# Altium schematic sheet Sumotop_Connectors_WB_SCH.SchDoc
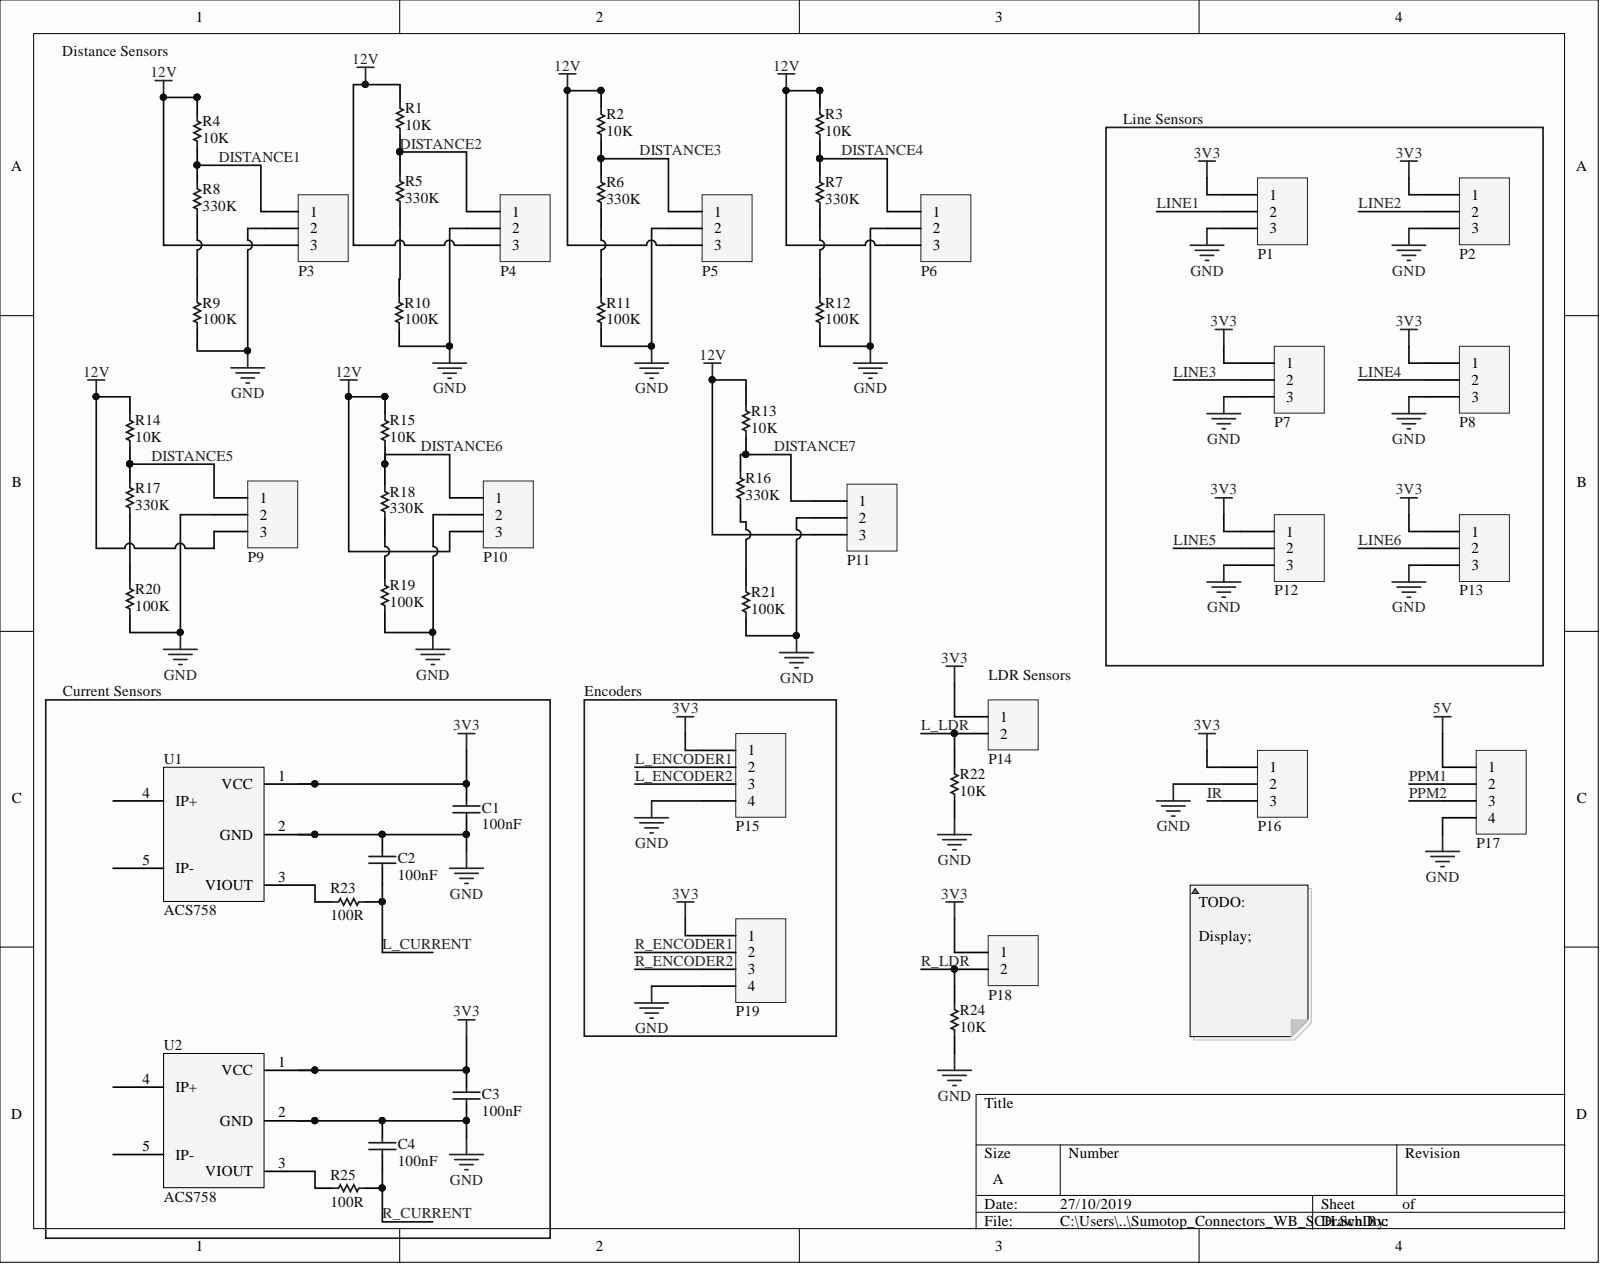
[diagram p0: Sumotop_Connectors_WB_SCH.SchDoc]

## Parts
C1  100nF  [Capacitor-0603]
C2  100nF  [Capacitor-0603]
C3  100nF  [Capacitor-0603]
C4  100nF  [Capacitor-0603]
P1  Header 1x3  [HDR MALE 1x3]  (value not drawn)
P2  Header 1x3  [HDR MALE 1x3]  (value not drawn)
P3  Header 1x3  [HDR MALE 1x3]  (value not drawn)
P4  Header 1x3  [HDR MALE 1x3]  (value not drawn)
P5  Header 1x3  [HDR MALE 1x3]  (value not drawn)
P6  Header 1x3  [HDR MALE 1x3]  (value not drawn)
P7  Header 1x3  [HDR MALE 1x3]  (value not drawn)
P8  Header 1x3  [HDR MALE 1x3]  (value not drawn)
P9  Header 1x3  [HDR MALE 1x3]  (value not drawn)
P10  Header 1x3  [HDR MALE 1x3]  (value not drawn)
P11  Header 1x3  [HDR MALE 1x3]  (value not drawn)
P12  Header 1x3  [HDR MALE 1x3]  (value not drawn)
P13  Header 1x3  [HDR MALE 1x3]  (value not drawn)
P14  Header 1x2  [HDR1X2]  (value not drawn)
P15  Header 1x4  [HDR MALE 1x4]  (value not drawn)
P16  Header 1x3  [HDR MALE 1x3]  (value not drawn)
P17  Header 1x4  [HDR MALE 1x4]  (value not drawn)
P18  Header 1x2  [HDR1X2]  (value not drawn)
P19  Header 1x4  [HDR MALE 1x4]  (value not drawn)
R1  10K  [RESISTOR 0603]
R2  10K  [RESISTOR 0603]
R3  10K  [RESISTOR 0603]
R4  10K  [RESISTOR 0603]
R5  330K  [RESISTOR 0603]
R6  330K  [RESISTOR 0603]
R7  330K  [RESISTOR 0603]
R8  330K  [RESISTOR 0603]
R9  100K  [RESISTOR 0603]
R10  100K  [RESISTOR 0603]
R11  100K  [RESISTOR 0603]
R12  100K  [RESISTOR 0603]
R13  10K  [RESISTOR 0603]
R14  10K  [RESISTOR 0603]
R15  10K  [RESISTOR 0603]
R16  330K  [RESISTOR 0603]
R17  330K  [RESISTOR 0603]
R18  330K  [RESISTOR 0603]
R19  100K  [RESISTOR 0603]
R20  100K  [RESISTOR 0603]
R21  100K  [RESISTOR 0603]
R22  10K  [RESISTOR 0603]
R23  100R  [RESISTOR 0603]
R24  10K  [RESISTOR 0603]
R25  100R  [RESISTOR 0603]
U1  ACS758  [ACS758]
U2  ACS758  [ACS758]

## Nets
3V3: C1.2 C3.2 P1.1 P2.1 P7.1 P8.1 P12.1 P13.1 P14.1 P15.1 P16.1 P18.1 P19.1 U1.1(VCC) U2.1(VCC)
5V: P17.1
12V: P3.3 P4.3 P5.3 P6.3 P9.3 P10.3 P11.3 R1.2 R2.2 R3.2 R4.2 R13.2 R14.2 R15.2
GND: C1.1 C2.2 C3.1 C4.2 P1.3 P2.3 P3.2 P4.2 P5.2 P6.2 P7.3 P8.3 P9.2 P10.2 P11.2 P12.3 P13.3 P15.4 P16.2 P17.4 P19.4 R9.1 R10.1 R11.1 R12.1 R19.1 R20.1 R21.1 R22.1 R24.1 U1.2(GND) U2.2(GND)
DISTANCE1: P3.1 R4.1 R8.2
DISTANCE2: P4.1 R1.1 R5.2
DISTANCE3: P5.1 R2.1 R6.2
DISTANCE4: P6.1 R3.1 R7.2
DISTANCE5: P9.1 R14.1 R17.2
DISTANCE6: P10.1 R15.1 R18.2
DISTANCE7: P11.1 R13.1 R16.2
IR: P16.3
L_CURRENT: C2.1 R23.2
L_ENCODER1: P15.2
L_ENCODER2: P15.3
L_LDR: P14.2 R22.2
LINE1: P1.2
LINE2: P2.2
LINE3: P7.2
LINE4: P8.2
LINE5: P12.2
LINE6: P13.2
PPM1: P17.2
PPM2: P17.3
R_CURRENT: C4.1 R25.2
R_ENCODER1: P19.2
R_ENCODER2: P19.3
R_LDR: P18.2 R24.2
NetR5_1: R5.1 R10.2
NetR6_1: R6.1 R11.2
NetR7_1: R7.1 R12.2
NetR8_1: R8.1 R9.2
NetR16_1: R16.1 R21.2
NetR17_1: R17.1 R20.2
NetR18_1: R18.1 R19.2
NetR23_1: R23.1 U1.3(VIOUT)
NetR25_1: R25.1 U2.3(VIOUT)

Not connected: U1 4(IP+) 5(IP-); U2 4(IP+) 5(IP-)

## Library data of the parts
C1-C4 = CAP 100NF 50V: Capacitor Cer disk 100nF 50V; Manufacturer Part Number: IMP14925; Capacitance: 0.1uF; Capacitance Tolerance: +80%, -20%; Capacitor Terminals: Radial Leaded; Dielectric Characteristic: Y5; Product Range: MCFY Series; SVHC: No SVHC (15-Jun-2015); Voltage Rating: 50; Capacitance Tolerance ±: +80%, -20%; Component Kind: Standard; ComponentLink1Description: http://loja.multcomercial.com.br/capacitor-ceramico-disco-100kpf-50v-104-100k-100nf-0-1uf-cod-1351.html; ComponentLink1URL: http://loja.multcomercial.com.br/capacitor-ceramico-disco-100kpf-50v-104-100k-100nf-0-1uf-cod-1351.html; Lead Spacing: 5; Manufacturer: -; Operating Temperature Max: 85; Operating Temperature Min: -25; Operating Temperature Range: -25°C to +85°C<!--FANDB--><div class="features-and-benefits-container"><div class="features-and-benefits-description"><span class="features-benefits-value">The MCFY series from Multicomp are radial leaded ceramic disc capacitors. The capacitance values ranges from 6800pF to 0.22?F.</span></div><div class="features-and-benefits-list"><ul class="features-and-benefits-list-list"><li class="features-and-benefits-list-item">Large capacitance in small size</li><li class="features-and-benefits-list-item">Rated voltage of 50V</li><li class="features-and-benefits-list-item">80%/-20% tolerance</li><li class="features-and-benefits-list-item">Operating temperature range -25°C to +85; Packaging: Each; Pricing 1: 1=0.10 (BRL); RoHS: YES; Stock 1: 115809; Supplier 1: Mult Comercial; Supplier Part Number 1: Capacitor Cerâmico Disco 100Kpf/50V (104/100K/100nF/0.1uF) cód. 1351; Library Name: Sumotop_Control_WB_SCHLIB.SchLib
P1-P13 P16 = Header 1x3: Headers & Wire Housings 40Pin 2mm Spacing Single Row Hdrs, 5pc; Manufacturer Part Number: IMP10327; Brand: Cromax; Mounting Angle: Vertical; Number of Positions: 40 Position; Accessory Type: -; Category: Headers & Wire Housings; Component Kind: Standard; ComponentLink1Description: http://loja.multcomercial.com.br/barra-de-pinos-simples-1x40-macho-bmo40-1e.html; ComponentLink1URL: http://loja.multcomercial.com.br/barra-de-pinos-simples-1x40-macho-bmo40-1e.html; Contact Gender: Pin (Male); Contact Plating: Gold; Contact Type: -; Manufacturer: Cromax; Minimum Order Quantity: 1; Mounting Style: Straight Pin; Number of Rows: 1 Row; Pitch: 2 mm; Pricing 1: 1=1.00, 5=0.20 (BRL); Product: Headers; Product Category: Accessories; Reeling: False; RoHS: RoHS Compliant; RoHS - Mouser: Y; Row Spacing: -; Stock 1: 14; Supplier 1: Mult Comercial; Supplier Part Number 1: Barra de Pinos Simples 1x40 Macho BMO40-1E; Termination Style: Through Hole; Type: Breakaway; Library Name: Sumotop_Control.SchLib
P14 P18 = Header 1x2: Headers & Wire Housings 40Pin 2mm Spacing Single Row Hdrs, 5pc; Manufacturer Part Number: IMP10327; Brand: Cromax; Mounting Angle: Vertical; Number of Positions: 40 Position; Accessory Type: -; Category: Headers & Wire Housings; Component Kind: Standard; ComponentLink1Description: http://loja.multcomercial.com.br/barra-de-pinos-simples-1x40-macho-bmo40-1e.html; ComponentLink1URL: http://loja.multcomercial.com.br/barra-de-pinos-simples-1x40-macho-bmo40-1e.html; Contact Gender: Pin (Male); Contact Plating: Gold; Contact Type: -; Manufacturer: Cromax; Minimum Order Quantity: 1; Mounting Style: Straight Pin; Number of Rows: 1 Row; Pitch: 2 mm; Pricing 1: 1=1.00, 5=0.20 (BRL); Product: Headers; Product Category: Accessories; Reeling: False; RoHS: RoHS Compliant; RoHS - Mouser: Y; Row Spacing: -; Stock 1: 14; Supplier 1: Mult Comercial; Supplier Part Number 1: Barra de Pinos Simples 1x40 Macho BMO40-1E; Termination Style: Through Hole; Type: Breakaway; Library Name: Sumotop_Control.SchLib
P15 P17 P19 = Header 1x4: Headers & Wire Housings 40Pin 2mm Spacing Single Row Hdrs, 5pc; Manufacturer Part Number: IMP10327; Brand: Cromax; Mounting Angle: Vertical; Number of Positions: 40 Position; Accessory Type: -; Category: Headers & Wire Housings; Component Kind: Standard; ComponentLink1Description: http://loja.multcomercial.com.br/barra-de-pinos-simples-1x40-macho-bmo40-1e.html; ComponentLink1URL: http://loja.multcomercial.com.br/barra-de-pinos-simples-1x40-macho-bmo40-1e.html; Contact Gender: Pin (Male); Contact Plating: Gold; Contact Type: -; Manufacturer: Cromax; Minimum Order Quantity: 1; Mounting Style: Straight Pin; Number of Rows: 1 Row; Pitch: 2 mm; Pricing 1: 1=1.00, 5=0.20 (BRL); Product: Headers; Product Category: Accessories; Reeling: False; RoHS: RoHS Compliant; RoHS - Mouser: Y; Row Spacing: -; Stock 1: 14; Supplier 1: Mult Comercial; Supplier Part Number 1: Barra de Pinos Simples 1x40 Macho BMO40-1E; Termination Style: Through Hole; Type: Breakaway; Library Name: Sumotop_Control.SchLib
R1-R4 R13-R15 R22 R24 = RES 10K 5%: Manufacturer: PANASONIC ELECTRONIC COMPONENTS; Manufacturer Part Number: ERJ-3GEYJ103V; Power (Watts): 0.1W, 1/10W; Temperature Coefficient: ±200ppm/°C; Tolerance: ±5%; Category: Resistors; Component Kind: Standard; ComponentLink1Description: http://www.farnell.com/datasheets/1678796.pdf; ComponentLink1URL: http://www.farnell.com/datasheets/1678796.pdf; ComponentLink2Description: http://industrial.panasonic.com/www-cgi/jvcr13pz.cgi?E+PZ+3+AOA0001+ERJ3GEYJ103V+7+WW; ComponentLink2URL: http://industrial.panasonic.com/www-cgi/jvcr13pz.cgi?E+PZ+3+AOA0001+ERJ3GEYJ103V+7+WW; Composition: Thick Film; Features: Automotive AEC-Q200; Height: 0.022" (0.55mm); Number of Terminations: 2; Operating Temperature: -55°C ~ 155°C; Package / Case: 0603 (1608 Metric); Packaging: Tape & Reel (TR); Resistance (Ohms): 10k; RoHS: YES; Size / Dimension: 0.063" L x 0.031" W (1.60mm x 0.80mm); Supplier Device Package: 0603; Library Name: Sumotop_Control_WB_SCHLIB.SchLib
R5-R8 R16-R18 = RES 330K 5%: Category: Resistors; Component Kind: Standard; ComponentLink1Description: http://www.farnell.com/datasheets/1678796.pdf; ComponentLink1URL: http://www.farnell.com/datasheets/1678796.pdf; ComponentLink2Description: http://industrial.panasonic.com/www-cgi/jvcr13pz.cgi?E+PZ+3+AOA0001+ERJ3GEYJ103V+7+WW; ComponentLink2URL: http://industrial.panasonic.com/www-cgi/jvcr13pz.cgi?E+PZ+3+AOA0001+ERJ3GEYJ103V+7+WW; Resistance (Ohms): 330k; Library Name: Sumotop_Control_WB_SCHLIB.SchLib
R9-R12 R19-R21 = RES 100K 5%: Category: Resistors; Component Kind: Standard; ComponentLink1Description: http://www.farnell.com/datasheets/1678796.pdf; ComponentLink1URL: http://www.farnell.com/datasheets/1678796.pdf; ComponentLink2Description: http://industrial.panasonic.com/www-cgi/jvcr13pz.cgi?E+PZ+3+AOA0001+ERJ3GEYJ103V+7+WW; ComponentLink2URL: http://industrial.panasonic.com/www-cgi/jvcr13pz.cgi?E+PZ+3+AOA0001+ERJ3GEYJ103V+7+WW; Resistance (Ohms): 100k; Library Name: Sumotop_Control_WB_SCHLIB.SchLib
R23 R25 = RES 100R 5%: Manufacturer: Ty-Ohm; Manufacturer Part Number: CR25; Power (Watts): 0.25W, 1/4W; Temperature Coefficient: 0/ -500ppm/°C; Tolerance: ±5%; Category: Resistors; Component Kind: Standard; ComponentLink1Description: http://loja.multcomercial.com.br/resistor-de-1-4-de-watt-cr25-5-de-tolerancia.html; ComponentLink1URL: http://loja.multcomercial.com.br/resistor-de-1-4-de-watt-cr25-5-de-tolerancia.html; Composition: Carbon Film; Features: Flame Retardant Coating, Safety; Height: -; Number of Terminations: 2; Operating Temperature: -55°C ~ 155°C; Package / Case: Axial; Packaging: Cut Tape (CT); Pricing 1: 1=0.20 (BRL); Resistance (Ohms): ?; RoHS: RoHS Compliant; Size / Dimension: 0.091" Dia x 0.256" L (2.30mm x 6.50mm); Stock 1: 617543; Supplier 1: Mult Comercial; Supplier Device Package: Axial; Supplier Part Number 1: Resistor de Filme de Carbono 1/4 de Watt CR25 5% de tolerância - 0.22R Ω à 10M Ω; Library Name: Sumotop_Control_WB_SCHLIB.SchLib
U1 U2 = ACS758KCB-150B: SENSOR CURRENT HALL 150A AC/DC; Manufacturer: Allegro MicroSystems; Manufacturer Part Number: ACS758KCB-150B-PFF-T; Accuracy: 1.6%; Bandwidth: 120kHz; Linearity: 1%; Max Supply Current: 13.5mA; Max Supply Voltage: 5.5V; Min Supply Voltage: 3V; Number of Channels: 1; Operating Supply Voltage: 5V; Output Current: 1mA; Polarity: Bidirectional; Response Time: 4us; Sensitivity: 13.3mV/A; Component Kind: Standard; ComponentLink1Description: https://datasheet.ciiva.com/15098/getdatasheetpartid-245779-15098873.pdf?src-supplier=Verical; ComponentLink1URL: https://datasheet.ciiva.com/15098/getdatasheetpartid-245779-15098873.pdf?src-supplier=Verical; ComponentLink2Description: https://datasheet.ciiva.com/3272/acs756-datasheet-3272283.pdf?src-supplier=Avnet; ComponentLink2URL: https://datasheet.ciiva.com/3272/acs756-datasheet-3272283.pdf?src-supplier=Avnet; ComponentLink3Description: https://datasheet.ciiva.com/16550/acs758-datasheet-16550653.pdf?src-supplier=Avnet+Europe; ComponentLink3URL: https://datasheet.ciiva.com/16550/acs758-datasheet-16550653.pdf?src-supplier=Avnet+Europe; Current Sensing: 150A; Frequency: 120kHz; Lead Free: Lead Free; Max Operating Temperature: 125°C; Min Operating Temperature: -40°C; Mount: Through Hole; Number of Pins: 5; Package Quantity: 34; Packaging: Rail/Tube; Pricing 1: 1 = 7.54000, 5 = 6.61600, 10 = 5.95400, 25 = 5.29200, 50 = 4.89520, 100 = 4.23360, 500 = 3.96900, 1000 = 3.70440 (USD); Radiation Hardening: No; REACH SVHC: No SVHC; RoHS: Yes; Stock 1: 2097; Supplier 1: Digi-Key; Supplier Part Number 1: 620-1322-ND; Library Name: Sumotop_Control.SchLib
